# Altium schematic sheet mainsheet1.SchDoc(mainsheet1)
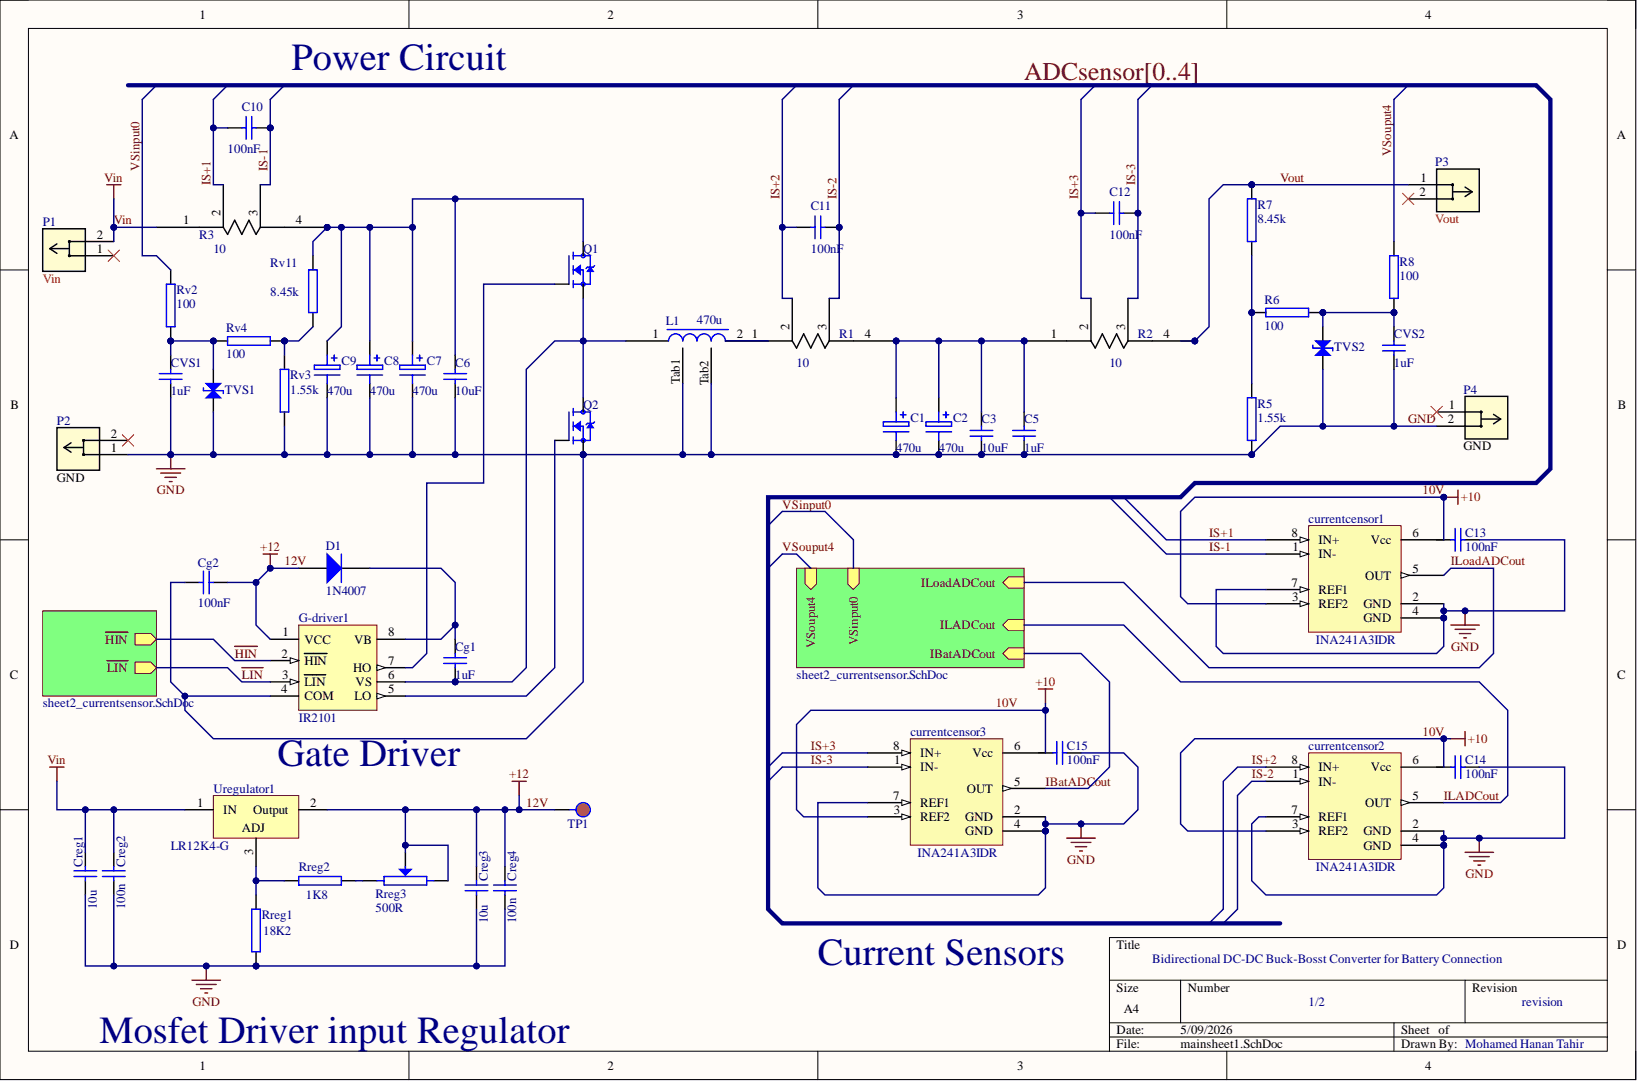
[diagram p0: mainsheet1.SchDoc]

## Parts
C1  470u
C2  470u
C3  10uF
C5  1uF
C6  10uF
C7  470u
C8  470u
C9  470u
C10  100nF
C11  100nF
C12  100nF
C13  100nF
C14  100nF
C15  100nF
Cg1  1uF
Cg2  100nF
Creg1  10u
Creg2  100n
Creg3  10u
Creg4  100n
currentcensor1  INA241A3IDR
currentcensor2  INA241A3IDR
currentcensor3  INA241A3IDR
CVS1  1uF
CVS2  1uF
D1  1N4007
G-driver1  IR2101
L1  470u
P1  Vin
P2  GND
P3  Vout
P4  GND
Q1  MCTL80N20Y-TP  (value not drawn)
Q2  MCTL80N20Y-TP  (value not drawn)
R1  10
R2  10
R3  10
R5  1.55k
R6  100
R7  8.45k
R8  100
Rreg1  18K2
Rreg2  1K8
Rreg3  500R
Rv2  100
Rv3  1.55k
Rv4  100
Rv11  8.45k
TP1  2mm Test Socket/PCB  (value not drawn)
TVS1  B72500D160H60  (value not drawn)
TVS2  B72500D160H60  (value not drawn)
Uregulator1  LR12K4-G

## Nets
10V: currentcensor1.3(REF2) currentcensor1.6(Vcc) currentcensor2.3(REF2) currentcensor2.6(Vcc) currentcensor3.3(REF2) currentcensor3.6(Vcc)
12V: Cg2.1 Creg3.2 Creg4.2 D1.A G-driver1.1(VCC) Rreg3.2 Rreg3.W TP1.1 Uregulator1.2
GND: C1.2 C2.2 C3.1 C5.2 C6.1 C7.2 C8.2 C9.2 C13.2 C14.2 C15.2 Cg2.2 Creg1.1 Creg2.1 Creg3.1 Creg4.1 currentcensor1.2(GND) currentcensor1.4(GND) currentcensor1.7(REF1) currentcensor2.2(GND) currentcensor2.4(GND) currentcensor2.7(REF1) currentcensor3.2(GND) currentcensor3.4(GND) currentcensor3.7(REF1) CVS1.1 CVS2.1 G-driver1.4(COM) L1.Tab1 L1.Tab2 P2.1 P4.2 Q2.2 R5.1 Rreg1.1 Rv3.1 TVS1.1 TVS2.1
~{HIN}: G-driver1.2(HIN)
IBatADCout: currentcensor3.5(OUT)
ILADCout: currentcensor2.5(OUT)
ILoadADCout: currentcensor1.5(OUT)
IS+1: C10.2 currentcensor1.8(IN+) R3.2
IS+2: C11.2 currentcensor2.8(IN+) R1.2
IS+3: C12.2 currentcensor3.8(IN+) R2.2
IS-1: C10.1 currentcensor1.1(IN-) R3.3
IS-2: C11.1 currentcensor2.1(IN-) R1.3
IS-3: C12.1 currentcensor3.1(IN-) R2.3
~{LIN}: G-driver1.3(LIN)
Vin: Creg1.2 Creg2.2 P1.2 R3.1 Uregulator1.1
Vout: P3.1 R2.4 R7.2
VSinput0: Rv2.1
VSouput4: R8.1
NetC1_1: C1.1 C2.1 C3.2 C5.1 R1.4 R2.1
NetC6_2: C6.2 C7.1 C8.1 C9.1 Q1.3 R3.4 Rv11.2
NetC13_1: C13.1
NetC14_1: C14.1
NetC15_1: C15.1
NetCg1_1: Cg1.1 D1.K G-driver1.8(VB)
NetCg1_2: Cg1.2 G-driver1.6(VS) L1.1 Q1.2 Q2.3
NetCVS1_2: CVS1.2 Rv2.2 Rv4.2 TVS1.2
NetCVS2_2: CVS2.2 R6.1 R8.2 TVS2.2
NetG-driver1_5: G-driver1.5(LO) Q2.1
NetG-driver1_7: G-driver1.7(HO) Q1.1
NetL1_2: L1.2 R1.1
NetP1_1: P1.1
NetP2_2: P2.2
NetP3_2: P3.2
NetP4_1: P4.1
NetR5_2: R5.2 R6.2 R7.1
NetRreg1_2: Rreg1.2 Rreg2.2 Uregulator1.3
NetRreg2_1: Rreg2.1 Rreg3.1
NetRv3_2: Rv3.2 Rv4.1 Rv11.1
ADCsensor0:  [also labelled ADCsensor]
ADCsensor1:  [also labelled ADCsensor]
ADCsensor2:  [also labelled ADCsensor]
ADCsensor3:  [also labelled ADCsensor]
ADCsensor4:  [also labelled ADCsensor]

## Library data of the parts
C1 C2 C7-C9 = CAPP200-400: Polarized Aluminum Capacitor, Through Hole, Pitch 200mil, Body 400mil (Dia); Component Kind: Standard; Library Name: Resistors and Capacitors.IntLib
C3 C5 C6 Cg1 Cg2 = CAPN 1210: Non Polarized Capacitor, SMD, 1210; Component Kind: Standard; Library Name: Resistors and Capacitors.IntLib
C10-C12 CVS1 CVS2 = B32652A6104J000: Film Capacitor, B32652 Series, 0.1 µF, ± 5%, PP (Polypropylene), 630 V; Component Kind: Standard; Library Name: Resistors and Capacitors.IntLib; Supplier 1: Farnell; Supplier Part Number 1: 1200782
C13-C15 = C0603C104K5RACTU: Capacitor, Ceramic, 0.1UF, 50V, X7R, 0603; Component Kind: Standard; Library Name: Resistors and Capacitors.IntLib; Supplier 1: Farnell; Supplier Part Number 1: 1301713
Creg1-Creg4 = CAPN 1206: Non Polarized Capacitor, SMD, 1206; Component Kind: Standard; Library Name: Resistors and Capacitors.IntLib
currentcensor1-currentcensor3 = INA241A3IDR: Current Sense Amp; Component Kind: Standard; Library Name: Projects_2025.IntLib
D1 = 1N4007: Diode, Standard, 1A, 1000v; Component Kind: Standard; Library Name: Diodes.IntLib; Supplier 1: Farnell; Supplier Part Number 1: 9549129
G-driver1 = IR2101_DIP: Half - Bridge Driver, MOSFET/IGBT, DIP8; Component Kind: Standard; Library Name: Projects_2025.IntLib; Supplier 1: Farnell; Supplier Part Number 1: 8638829
L1 = AGP4233Hi: Power Inductor   Through hole; Component Kind: Standard; Library Name: Projects_2025.IntLib
P1-P4 = Banana PCB Horizontal: Horizontal PCB Mounted Banana Socket 24.243.2; Mfr_Name: Multicomp; Component Kind: Standard; Library Name: Projects_2025 (2).IntLib; RefDes: RefDes; Type: Type
Q1 Q2 = MCTL80N20Y-TP: N-Channel 200 V 80A (Tc) 250W (Tj) Surface Mount TOLL-8L; Component Kind: Standard; Library Name: Projects_2025.IntLib
R1-R3 = WSL3673R-01: Current Shunt   Kelvin 4W 0.002- 0.01; Component Kind: Standard; Library Name: Projects_2025.IntLib
R5-R8 Rreg1 Rreg2 Rv2-Rv4 Rv11 = Resistor 1206: Resistor, SMD, 1206; Component Kind: Standard; Library Name: Resistors and Capacitors.IntLib
Rreg3 = 3296W-1-104LF: 3296W Series, 3/8 ” Square Potentiometer, 100k, 10%,  0.5 Watts, Multi Turn    Part #: PV36W501C01B00; Component Kind: Standard; Supplier 1: Farnell; Supplier Part Number 1: 9353194; Library Name: Potentiometers.IntLib
TP1 = 11013-R: Banana Socket, 2mm, PCB, RED; Component Kind: Standard; Library Name: Projects_2018.IntLib; Supplier 1: Mouser; Supplier Part Number 1: 534-11013-R
TVS1 TVS2 = B72500D160H60: TVS Diodes - Transient Voltage Suppressors; Component Kind: Standard; Library Name: Diodes.IntLib; Supplier 1: Farnell; Supplier Part Number 1: 1832649
Uregulator1 = LR12K4-G: Adj Positive Regulator   Linear. 12V dropout V.   2W; Component Kind: Standard; Library Name: Projects_2025.IntLib
